# Altium schematic sheet Power.SchDoc
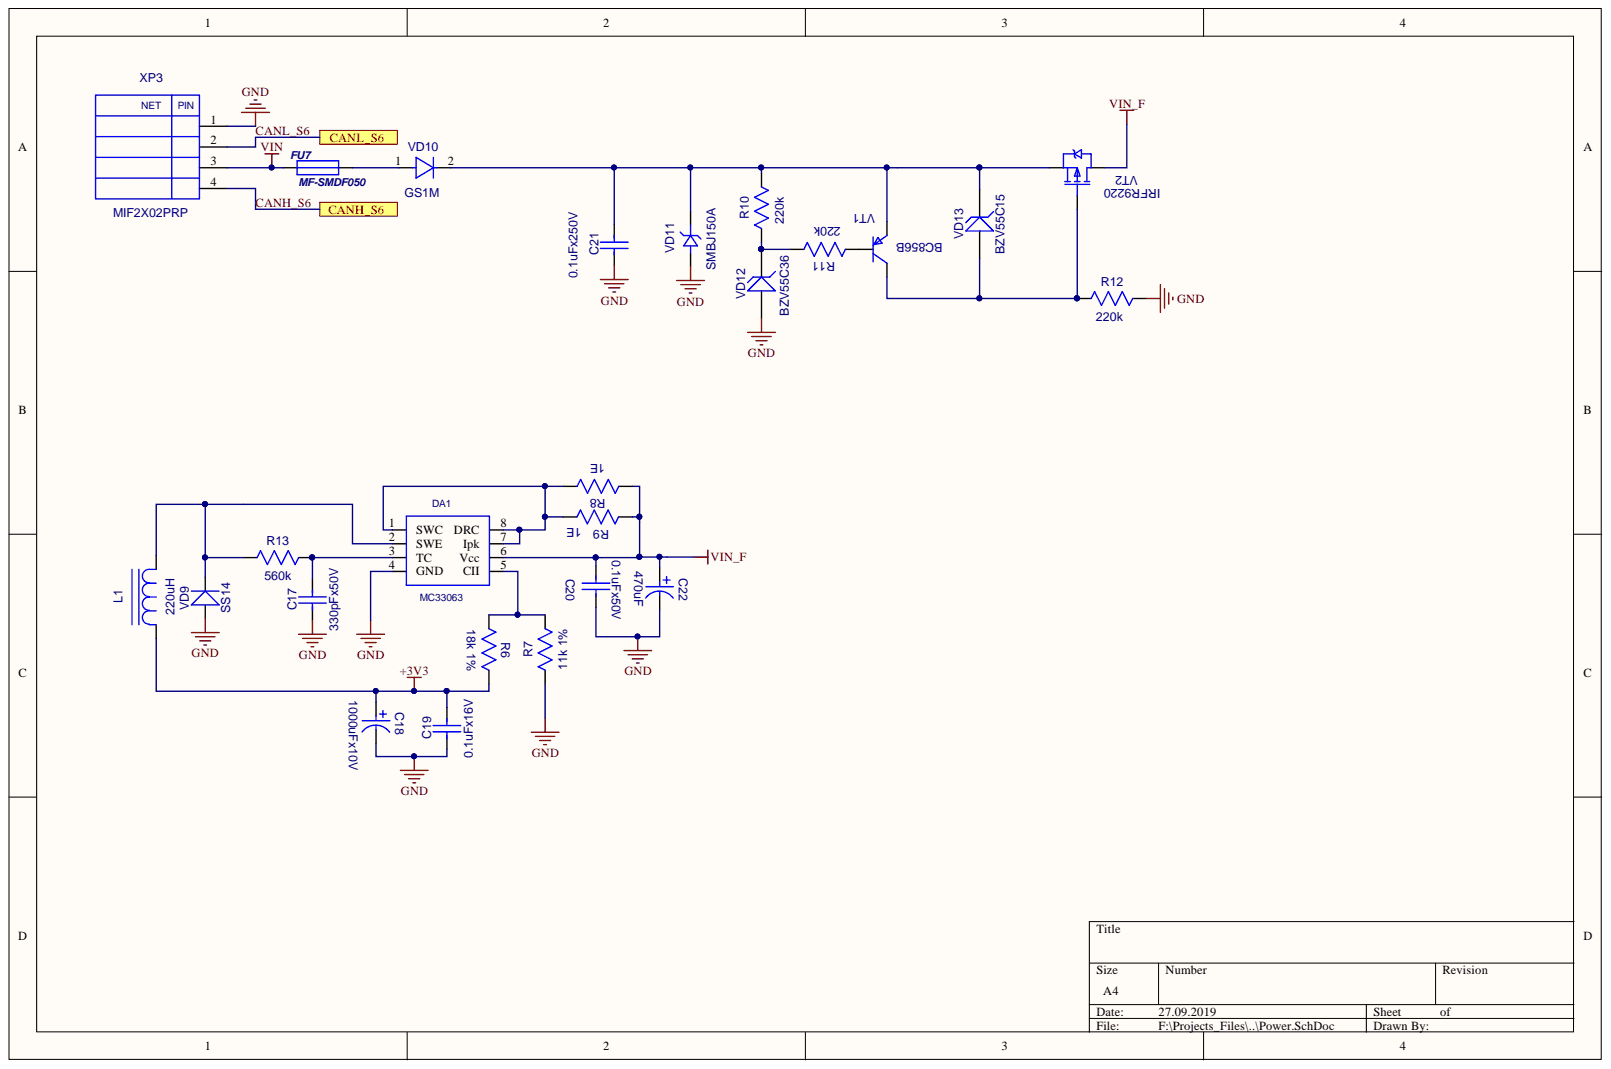
[diagram p0: Power.SchDoc]

## Parts
C17  330pFx50V  [CC0603-3M]
C18  1000uFx10V  [CC8X3.5]
C19  0.1uFx16V  [CC0603-3M]
C20  0.1uFx50V  [CC0603-3M]
C21  0.1uFx250V  [CC1206-MIDI]
C22  470uF  [CC16X10_CAP0.5(1)(Primary)]
DA1  MC33063  [SO-8]
FU7  MF-SMDF050  [MF-SMDF]
L1  220uH  [SDR0805]
R6  18k 1%  [RC0603-3M]
R7  11k 1%  [RC0603-3M]
R8  1E  [RC0805_IPC_NOM]
R9  1E  [RC0805_IPC_NOM]
R10  220k  [RC1206-2M]
R11  220k  [RC1206-2M]
R12  220k  [RC1206-2M]
R13  560k  [RC0603-3M]
VD9  SS14  [SMA]
VD10  GS1M  [SMA]
VD11  SMBJ150A  [SMB]
VD12  BZV55C36  [SOD-80]
VD13  BZV55C15  [SOD-80]
VT1  BC856B  [SOT-23(123)]
VT2  IRFR9220  [D-PAK(TO-252AA)]
XP3  MIF2X02PRP  [MIF2X02PRP]

## Ports
CANH_S6, CANL_S6

## Nets
+3V3: C18.1 C19.2 L1.1 R6.2
GND: C17.1 C18.2 C19.1 C20.2 C21.1 C22.1 DA1.4(GND) R7.1 R12.2 VD9.1 VD11.1 VD12.1 XP3.1
VIN: FU7.1 XP3.3
VIN_F: C20.1 C22.2 DA1.6(Vcc) R8.1 R9.1 VT2.3
CANH_S6: XP3.4 ; port CANH_S6
CANL_S6: XP3.2 ; port CANL_S6
NetC17_2: C17.2 DA1.3(TC) R13.2
NetC21_2: C21.2 R10.2 VD10.2 VD11.2 VD13.2 VT1.2 VT2.2
NetDA1_1: DA1.1(SWC) DA1.7(Ipk) DA1.8(DRC) R8.2 R9.2
NetDA1_2: DA1.2(SWE) L1.2 R13.1 VD9.2
NetDA1_5: DA1.5(CII) R6.1 R7.2
NetFU7_2: FU7.2 VD10.1
NetR10_1: R10.1 R11.2 VD12.2
NetR11_1: R11.1 VT1.1
NetR12_1: R12.1 VD13.1 VT1.3 VT2.1

## Library data of the parts
C17 C19 C20 = CC0603: Component Kind: Standard; Description: CC0603; Library Name: gprs_modem.SchLib
  C17: Value: 330pFx50V
  C19: Value: 0.1uFx16V
  C20: Value: 0.1uFx50V
C18 = CAP0.35: Component Kind: Standard; Description: CAP0.35; Value: 1000uFx10V; Library Name: gprs_modem.SchLib
C21 = CC1206: Component Kind: Standard; Description: CC1206; Value: 0.1uFx250V; Library Name: gprs_modem.SchLib
C22 = CAP0.5(1): Component Kind: Standard; Description: CAP0.5(1); Value: 470uF; Library Name: gprs_modem.SchLib
DA1 = MC34063: Component Kind: Standard; Description: MC34063; Value: MC33063; Library Name: Schetchik.SchLib
FU7 = MF-SMDF: Component Kind: Standard; Description: MF-SMDF; Value: MF-SMDF050; Library Name: gprs_modem.SchLib
L1 = SDR0805: Component Kind: Standard; Description: SDR0805; Value: 220uH; Library Name: gprs_modem.SchLib
R6 R7 R13 = RC0603: Component Kind: Standard; Description: RC0603; Library Name: gprs_modem.SchLib
  R6: Value: 18k 1%
  R7: Value: 11k 1%
  R13: Value: 560k
R8 R9 = RC0805: Component Kind: Standard; Description: RC0805; Value: 1E; Library Name: gprs_modem.SchLib
R10-R12 = RC1206: Component Kind: Standard; Description: RC1206; Value: 220k; Library Name: gprs_modem.SchLib
VD9 = SS14: Schottky Rectifier; Component Kind: Standard; Value: SS14; Library Name: gprs_modem.SchLib
VD10 = GS1M: Value: {Value}; Component Kind: Standard; Description: GS1M; Library Name: Schetchik.SchLib
VD11 = SMBJ: Component Kind: Standard; Description: SMBJ; Value: SMBJ150A; Library Name: gprs_modem.SchLib
VD12 VD13 = BZV55C12: Zener Voltage Regulator Diode; ComponentField6: Pin 2 is not connected and not drawn.; ComponentField7: Published 29-Sep-2000; OriginalName: BZX84C10LT1; Component Kind: Standard; Simulation: BZX84C10LT1; Library Name: gprs_modem.SchLib
  VD12: Value: BZV55C36
  VD13: Value: BZV55C15
VT1 = BC856B: ComponentField7: Published 29-Sep-2000; OriginalName: BC856BLT; Component Kind: Standard; Description: BC856B; Simulation: BC846BLT1; Value: BC856B; Library Name: Schetchik.SchLib
VT2 = IRFR9220: HEXFET Power MOSFET; ComponentField7: Published 8-Jun-2000; OriginalName: IRLML5103; Component Kind: Standard; Value: IRFR9220; Library Name: gprs_modem.SchLib
XP3 = MIF2X02PRP: Component Kind: Standard; Description: MIF2X02PRP; Library Name: Schetchik.SchLib
